# Altium schematic sheet MCU.SchDoc
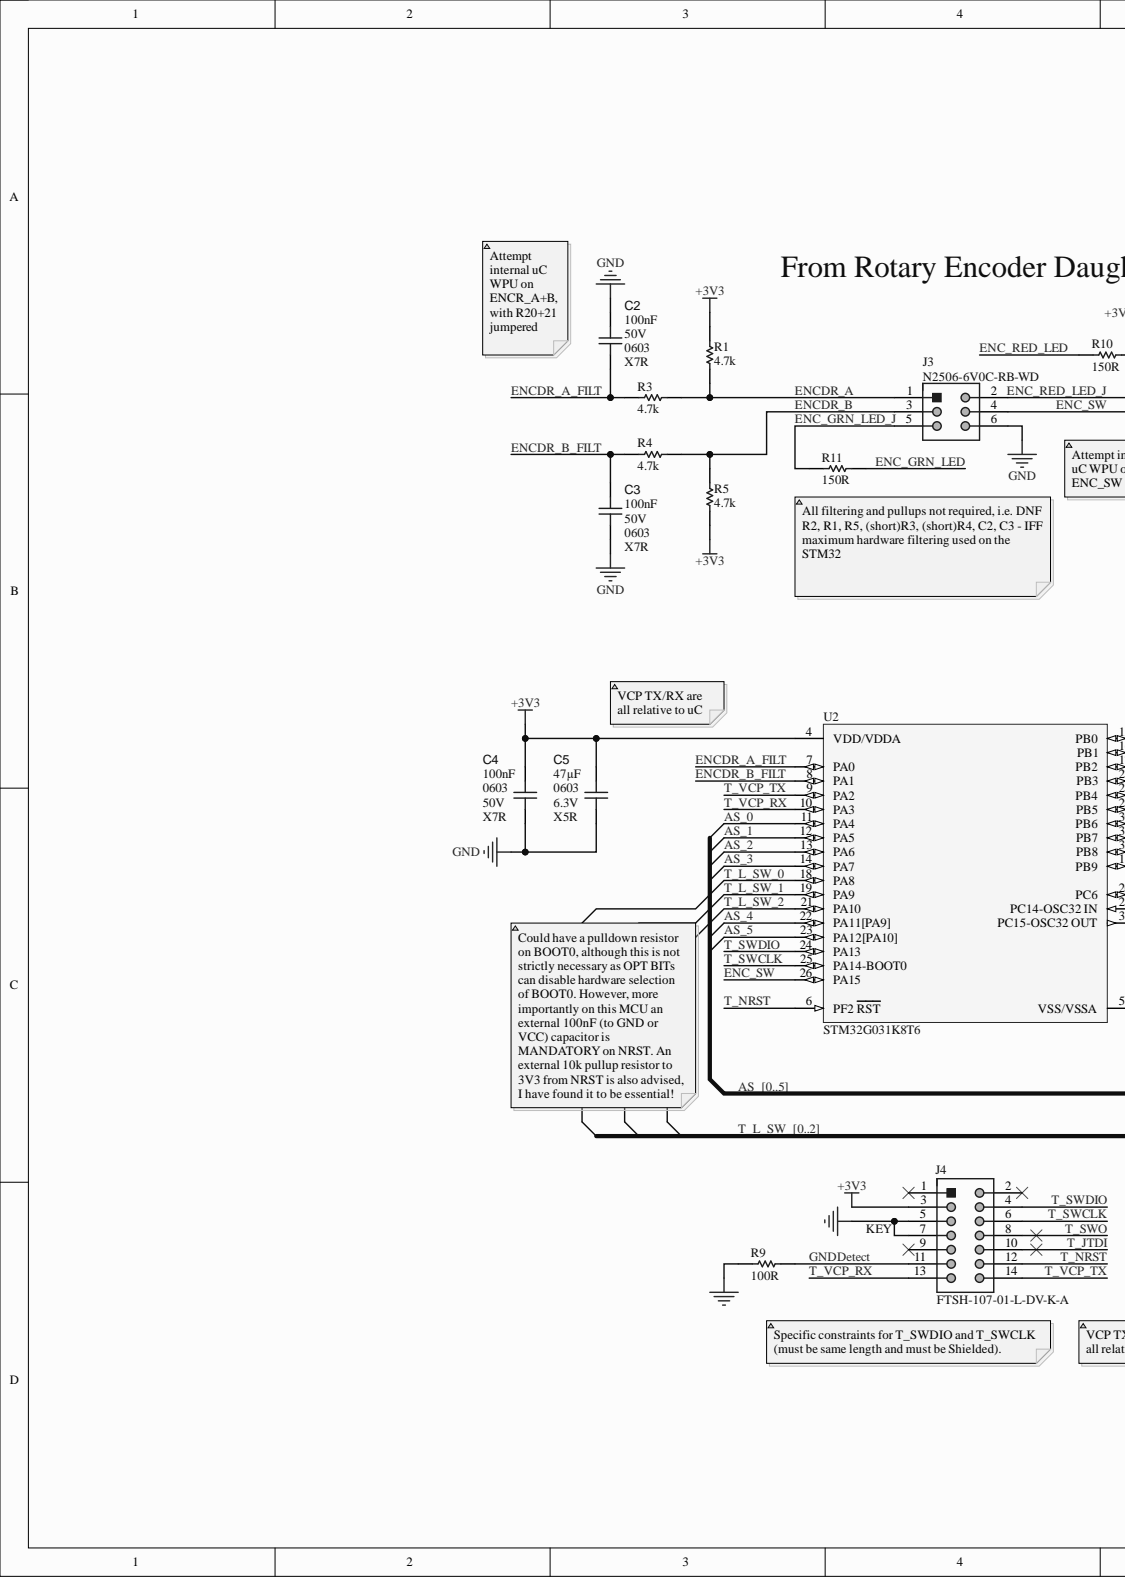
[diagram p0: MCU.SchDoc, left]
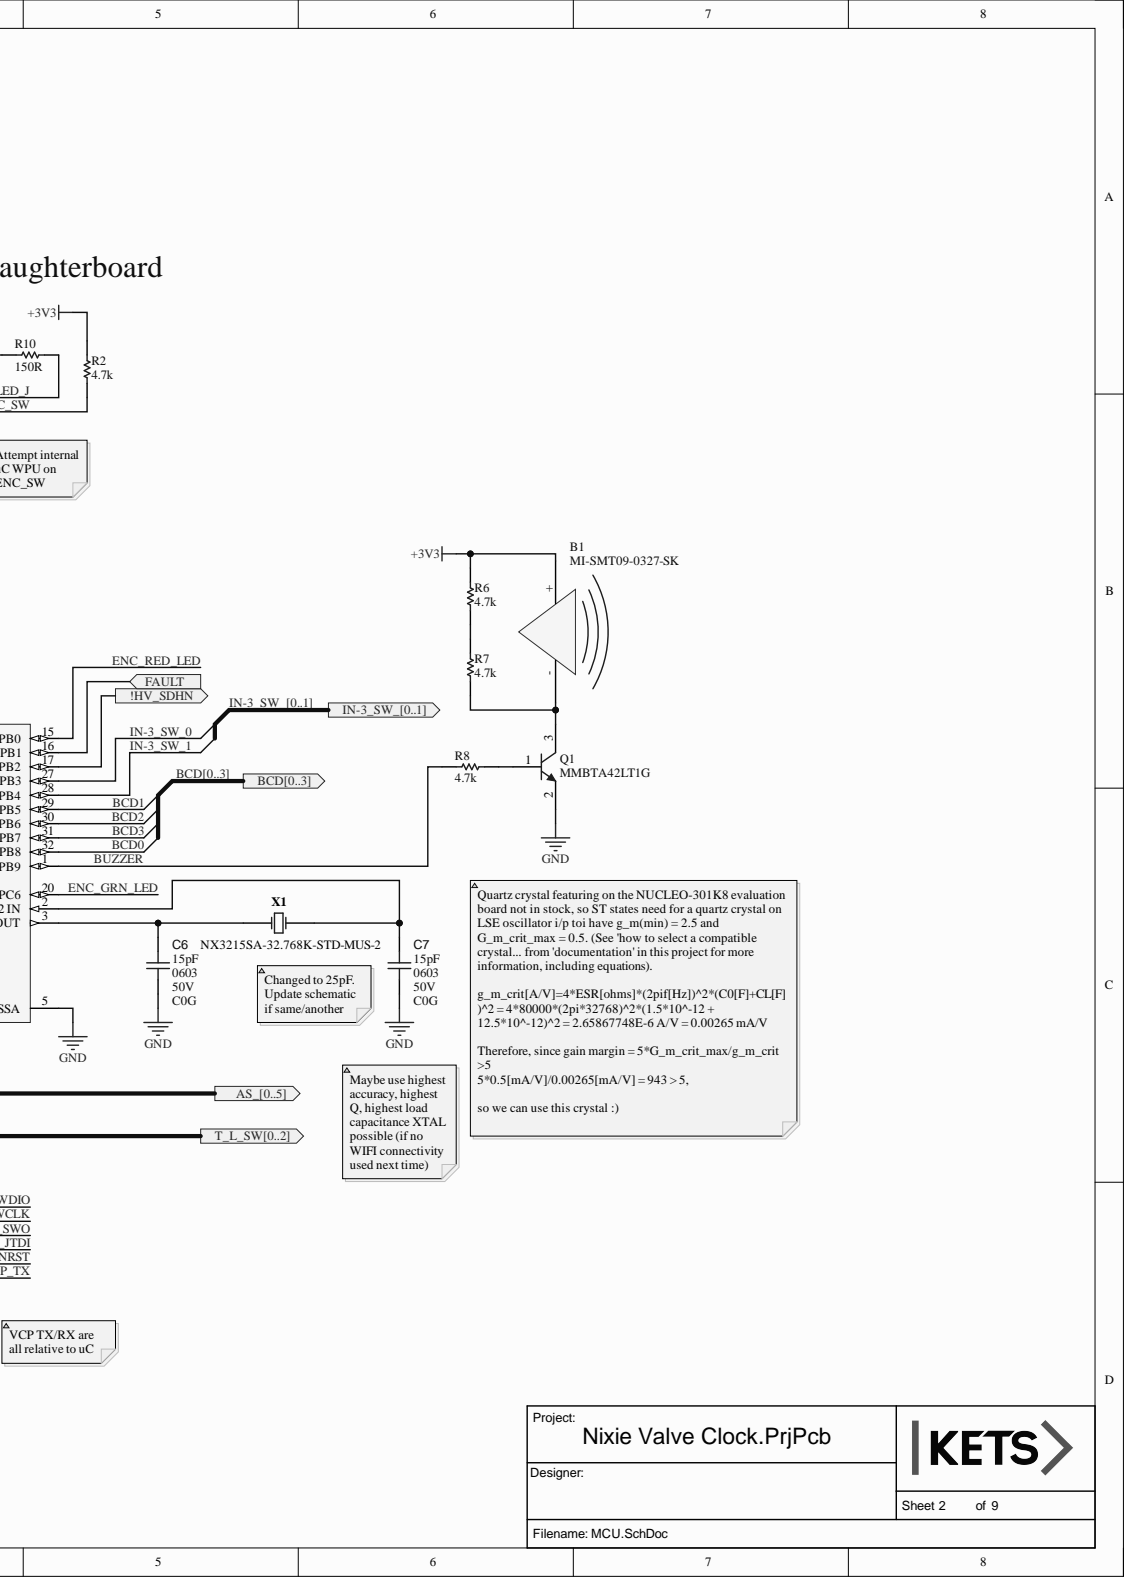
[diagram p1: MCU.SchDoc, right]

## Parts
B1  MI-SMT09-0327-SK  [INDP9696X53N]
C2  value 100nF  Case/Package: 0603  Dielectric: X7R  Rated DC Voltage (URdc): 50V  [CAPC1608X09N]  (hidden comment: CGA3E2X7R1H104K080AA)
C3  value 100nF  Case/Package: 0603  Dielectric: X7R  Rated DC Voltage (URdc): 50V  [CAPC1608X09N]  (hidden comment: CGA3E2X7R1H104K080AA)
C4  value 100nF  Case/Package: 0603  Dielectric: X7R  Rated DC Voltage (URdc): 50V  [CAPC1608X09N]  (hidden comment: CGA3E2X7R1H104K080AA)
C5  value 47µF  Case/Package: 0603  Dielectric: X5R  Voltage Rating: 6.3V  [CAPC1608X87X35NL15T15]  (hidden comment: 06036D476MAT2A)
C6  value 15pF  Case Code (Imperial): 0603  Dielectric: C0G  Voltage Rating: 50V  [CAPC1608X87X35NL15T15]  (hidden comment: C0603X150J5GACTU)
C7  value 15pF  Case Code (Imperial): 0603  Dielectric: C0G  Voltage Rating: 50V  [CAPC1608X87X35NL15T15]  (hidden comment: C0603X150J5GACTU)
J3  N2506-6V0C-RB-WD  [N2506-6V0C-RB-WD-Footprint-1]
J4  FTSH-107-01-L-DV-K-A  [FP-FTSH-107-01-L-DV-K-A-MFG]
Q1  MMBTA42LT1G  [ONSC-SOT-23-3-318-08_V]
R1  value 4.7k  [RESC1608X55X25NL10T15]  (hidden comment: Resistor 4k7 +/-1% 0603 100 mW)
R2  value 4.7k  [RESC1608X55X25NL10T15]  (hidden comment: Resistor 4k7 +/-1% 0603 100 mW)
R3  value 4.7k  [RESC1608X55X25NL10T15]  (hidden comment: Resistor 4k7 +/-1% 0603 100 mW)
R4  value 4.7k  [RESC1608X55X25NL10T15]  (hidden comment: Resistor 4k7 +/-1% 0603 100 mW)
R5  value 4.7k  [RESC1608X55X25NL10T15]  (hidden comment: Resistor 4k7 +/-1% 0603 100 mW)
R6  value 4.7k  [RESC1608X55X25NL10T15]  (hidden comment: Resistor 4k7 +/-1% 0603 100 mW)
R7  value 4.7k  [RESC1608X55X25NL10T15]  (hidden comment: Resistor 4k7 +/-1% 0603 100 mW)
R8  value 4.7k  [RESC1608X55X25NL10T15]  (hidden comment: Resistor 4k7 +/-1% 0603 100 mW)
R9  value 100R  [RESC1608X55X25NL10T15]  (hidden comment: ERJ-3EKF1000V)
R10  value 150R  [RESC1608X55X25NL10T15]  (hidden comment: CRCW0603150RFKEAHP)
R11  value 150R  [RESC1608X55X25NL10T15]  (hidden comment: CRCW0603150RFKEAHP)
U2  STM32G031K8T6  [TQFP80P900X900X160-32N]
X1  NX3215SA-32.768K-STD-MUS-2  [NX3215SA-32.768K-STD-MUS-2-Footprint-1]

## Ports
!HV_SDHN, AS_0, AS_1, AS_2, AS_3, AS_4, AS_5, AS_[0..5], BCD0, BCD1, BCD2, BCD3, BCD[0..3], FAULT, IN-3_SW_0, IN-3_SW_1, IN-3_SW_[0..1], T_L_SW0, T_L_SW1, T_L_SW2, T_L_SW[0..2]

## Nets
+3V3: B1.+ C4.1 C5.1 J4.3 R1.2 R2.2 R5.1 R6.2 U2.4(VDD/VDDA)
GND: C2.2 C3.1 C4.2 C5.2 C6.2 C7.2 J3.6 J4.5 J4.7 Q1.2 R9.1 U2.5(VSS/VSSA)
!HV_SDHN: U2.17(PB2) ; port !HV_SDHN
AS_0: U2.11(PA4) ; port AS_[0..5]  [also labelled AS_]
AS_1: U2.12(PA5) ; port AS_[0..5]  [also labelled AS_]
AS_2: U2.13(PA6) ; port AS_[0..5]  [also labelled AS_]
AS_3: U2.14(PA7) ; port AS_[0..5]  [also labelled AS_]
AS_4: U2.22(PA11[PA9]) ; port AS_[0..5]  [also labelled AS_]
AS_5: U2.23(PA12[PA10]) ; port AS_[0..5]  [also labelled AS_]
BCD0: U2.32(PB8) ; port BCD[0..3]  [also labelled BCD]
BCD1: U2.29(PB5) ; port BCD[0..3]  [also labelled BCD]
BCD2: U2.30(PB6) ; port BCD[0..3]  [also labelled BCD]
BCD3: U2.31(PB7) ; port BCD[0..3]  [also labelled BCD]
BUZZER: R8.1 U2.1(PB9)
ENC_GRN_LED: R11.2 U2.20(PC6)
ENC_GRN_LED_J: J3.5 R11.1
ENC_RED_LED: R10.2 U2.15(PB0)
ENC_RED_LED_J: J3.2 R10.1
ENC_SW: J3.4 R2.1 U2.26(PA15)
ENCDR_A: J3.1 R1.1 R3.2
ENCDR_A_FILT: C2.1 R3.1 U2.7(PA0)
ENCDR_B: J3.3 R4.2 R5.2
ENCDR_B_FILT: C3.2 R4.1 U2.8(PA1)
FAULT: U2.16(PB1) ; port FAULT
GNDDetect: J4.11 R9.2
IN-3_SW_0: U2.27(PB3) ; port IN-3_SW_[0..1]  [also labelled IN-3_SW_]
IN-3_SW_1: U2.28(PB4) ; port IN-3_SW_[0..1]  [also labelled IN-3_SW_]
T_JTDI: J4.10
T_L_SW_0: U2.18(PA8) ; port T_L_SW[0..2]  [also labelled T_L_SW_]
T_L_SW_1: U2.19(PA9) ; port T_L_SW[0..2]  [also labelled T_L_SW_]
T_L_SW_2: U2.21(PA10) ; port T_L_SW[0..2]  [also labelled T_L_SW_]
T_NRST: J4.12 U2.6(PF2_RST)
T_SWCLK: J4.6 U2.25(PA14-BOOT0)
T_SWDIO: J4.4 U2.24(PA13)
T_SWO: J4.8
T_VCP_RX: J4.13 U2.10(PA3)
T_VCP_TX: J4.14 U2.9(PA2)
NetB1_-: B1.- Q1.3 R7.1
NetC6_1: C6.1 U2.3(PC15-OSC32_OUT) X1.1
NetC7_1: C7.1 U2.2(PC14-OSC32_IN) X1.2
NetJ4_1: J4.1
NetJ4_2: J4.2
NetJ4_9: J4.9
NetQ1_1: Q1.1 R8.2
NetR6_1: R6.1 R7.2

## Library data of the parts
B1 = CMP-00030-00004-2: Magnetic Indicator SMT 9.6MM 3V; Component Kind: Standard; Mounting Technology: SMT; Pins: 2; RoHS Compliant: Yes; Library Name: KETS Quantum Security
C2-C4 = CMP-001-00101-1: Ceramic Capacitor, Multilayer, Ceramic, 50V, 10% +Tol, 10% -Tol, X7R, 15% TC, 0.1uF, Surface Mount, 0603; Multilayer: Yes; Reference Standard: AEC-Q200; Capacitor Type: Ceramic; Component Kind: Standard; Dielectric Material: Ceramic; Height: 0.8mm; JESD-609 Code: e3; Length: 1.6mm; Max Operating Temperature: 125°C; Min Operating Temperature: -55°C; Mounting Technology: Surface Mount; Package Shape: Rectangular; Package Style: SMTMeter; Packaging: Tape and Reel; Pins: 2; Reach SVHC Compliant: Yes; RoHS Compliant: Yes; Temperature Characteristics Code: X7R; Terminal Finish: Nickel; Termination: Wraparound; Tolerance: 10%; Tolerance  (fill in as +-): 10%; Tolerance (fill in as +-): 10%; Voltage Rating: 50V; Width: 0.8mm; Library Name: KETS Quantum Security
C5 = CMP-00388-1: 0603 (1608Metric) CERAMIC CAPACITOR ceramic capacitor 6.3V 47uF ±20% X5R; Capacitor Type: MLCC; Component Kind: Standard; Dielectric Material: Ceramic; Height: 1.02mm; Length: 1.6mm; Lifecycle Status: Production; Material: Ceramic; Max Operating Temperature: 85°C; Min Operating Temperature: -55°C; Mounting Technology: Surface Mount; Number of Terminals: 2; Pins: 2; REACH SVHC: Yes; RoHS: Compliant; RoHS Compliant: Yes; Schedule B: 8532240020; Tolerance: 20%; Voltage Rating (DC): 6.3V; Width: 800µm; Library Name: KETS Quantum Security
C6 C7 = CMP-00385-1: Cap Ceramic 15pF 50V C0G 5% Pad SMD 0603 Flexible Termination 125C Extreme Low ESR T/R; Case Code (Metric): 1608; Case/Package: 0603; China RoHS: Compliant; Component Kind: Standard; Depth: 800µm; Dielectric Material: Ceramic; Features: Soft Termination; Height: 800µm; Lead Free: Lead Free; Length: 1.6mm; Lifecycle Status: Production; Max Operating Temperature: 125°C; Min Operating Temperature: -55°C; Mounting Technology: Surface Mount; Number of Terminals: 2; Packaging: Tape & Reel; Pins: 2; Radiation Hardening: No; REACH SVHC: Yes; RoHS: Compliant; RoHS Compliant: Yes; Schedule B: 8532240020, 8532240020; Termination: SMD/SMT; Thickness: 863.6µm; Tolerance: 5%; Voltage Rating (DC): 50V; Width: 800µm; Library Name: KETS Quantum Security
J3 = CMP-002-00208-1: 80001386988 N2506-6V0C-Rb-Wd=06//Ht/Vsmt/30U/Rohs/Cap/T&r; Connector Type: Male Pin; Current Rating: 5A; Insulation Resistance: 1GΩ; Number of Contacts: 6; Pitch: 2.54mm; Plating Thickness: 762nm; Row Spacing: 6.86mm; Color: Black; Component Kind: Standard; Contact Gender: Male; Contact Material: Gold, Tin; Contact Mating Length: 6.1722mm; Contact Plating: Gold; Height: 11.06mm; Lifecycle Status: Production; Max Operating Temperature: 105°C; Min Operating Temperature: -55°C; Mounting Technology: Surface Mount; Number of Positions: 6; Number of Rows: 2; Pins: 6; REACH SVHC: Yes; RoHS: Compliant; Terminal Pitch: 2.54mm; Termination: Solder; Library Name: KETS Quantum Security
J4 = CMP-002-00203-1: Conn Unshrouded Header HDR 14 POS 1.27mm Solder ST Top Entry SMD TIGER CLAW™ Tube; Manufacturer Lifecycle Status: PRODUCTION; Current Rating: 3.4A; Gender: Male; Number of Contacts: 14; Component Kind: Standard; Contact Material: Gold, Nickel; Contact Plating: Gold; Height: 6.38mm; Lead Free: Lead Free; Lifecycle Status: Production; Max Operating Temperature: 125°C; Min Operating Temperature: -55°C; Number of Rows: 2; Orientation: Vertical; Pins: 14; Pitch: 1.27mm; RoHS: Compliant; Schedule B: 8536694040; Termination: Solder; Library Name: KETS Quantum Security
Q1 = CMP-013-00062-1: Bipolar (BJT) Single Transistor, General Purpose, NPN, 300 V, 500 mA, 225 mW, SOT-23, Surface Mount; Manufacturer Lifecycle Status: ACTIVE; Clock Frequency: 50MHz; Collector Base Voltage (VCBO): 300V; Collector Emitter Saturation Voltage: 500mV; Current Rating: 500mA; Emitter Base Voltage (VEBO): 6V; hFE Min: 40; Max Power Dissipation: 300mW; Number of Elements: 1; Case/Package: SOT-23-3; China RoHS: Compliant; Collector Emitter Breakdown Voltage: 300V; Collector Emitter Voltage (VCEO): 300V; Component Kind: Standard; Contact Plating: Tin; Element Configuration: Single; Frequency: 50MHz; Gain Bandwidth Product: 50MHz; Height: 1.016mm; Lead Free: Lead Free; Length: 3.0226mm; Lifecycle Status: Production; Max Breakdown Voltage: 300V; Max Collector Current: 500mA; Max Operating Temperature: 150°C; Min Operating Temperature: -55°C; Number of Terminals: 3; Packaging: Tape and Reel; Pins: 3; Polarity: NPN; Radiation Hardening: No; REACH SVHC: Yes; RoHS: Compliant; Schedule B: 8541210080, 8541210080; Transition Frequency: 50MHz; Voltage Rating (DC): 300V; Weight: 4.535924g; Width: 1.397mm; Library Name: KETS Quantum Security
R1-R8 = CMP-009-00031-13: Chip Resistor, 4.7 KOhm, +/- 1%, 0.1 W, -55 to 155 degC, 0603 (1608 Metric); Power: 100mW; Case/Package: 0603; Component Kind: Standard; Max Operating Temperature: 155°C; Min Operating Temperature: -55°C; Mounting Technology: SM; Pins: 2; RoHS Compliant: True; Tolerance: 1%; Library Name: KETS Quantum Security
R9 = CMP-00265-1: Fixed Resistor, Metal Glaze/thick Film, 0.1W, 100ohm, 75V, 1% +/-Tol, 100ppm/Cel, Surface Mount, 0603; Package Height: 0.45mm; Package Length: 1.6mm; Package Width: 0.8mm; Power: 100mW; Rated Temperature: 70°C; Reference Standard: AEC-Q200; Temperature Coefficient (ppm/Cel): 100; Case/Package: 0603; Component Kind: Standard; Construction: Rectangular; JESD-609 Code: e3; Max Operating Temperature: 155°C; Min Operating Temperature: -55°C; Mounting Technology: Surface Mount; Package Shape: Rectangular; Packaging: Tape and Reel; Pins: 2; Reach SVHC Compliant: Yes; Resistor Type: FIXED RESISTOR; RoHS Compliant: Yes; Technology: METAL GLAZE/THICK FILM; Terminal Finish: Nickel; Termination: Wraparound; Tolerance: 1%; Voltage Rating: 75V; Working Voltage: 75V; Library Name: KETS Quantum Security
R10 R11 = CMP-00382-2: Res Thick Film 0603 150 Ohm 1% 1/3W ±100ppm/°C Molded SMD SMD Paper T/R; Max Power Dissipation: 250mW; Power: 100mW; Power Dissipation: 330mW; Voltage Rating: 75V; Case Code (Imperial): 0603; Case Code (Metric): 1608; Case/Package: 0603; China RoHS: Non-Compliant; Component Kind: Standard; Composition: Thick Film; Contact Plating: Tin; Depth: 850µm; Features: Automotive AEC-Q200; Height: 450µm; Lead Free: Lead Free; Length: 1.55mm; Lifecycle Status: Production; Max Operating Temperature: 155°C; Military Standard: Not; Min Operating Temperature: -55°C; Mounting Technology: Surface Mount; Number of Terminals: 2; Number of Terminations: 2; Packaging: Cut Tape; Pins: 2; Power Rating: 330mW; Radiation Hardening: No; REACH SVHC: Yes; RoHS: Compliant; RoHS Compliant: Yes; Schedule B: 8533210045, 8533210045; Temperature Coefficient: 100ppm/°C; Termination: SMD/SMT; Tolerance: 1%; Voltage Rating (DC): 75V; Weight: 2.012816mg; Width: 850µm; Working Voltage: 75V; Library Name: KETS Quantum Security
U2 = CMP-00383-2: RISC Microcontroller, 32-Bit, FLASH, CORTEX-M0 CPU, 64MHz, CMOS, PQFP32; ADC Channels (Resolution): 16-Ch 12-Bit; Clock Frequency: 48MHz; Core Architecture: ARM Cortex-M0+; Data Bus Width: 32b; Data Memory: 8K; EEPROM Memory Size: 0B; Flash Memory Size: 64kB; Interface: I2C, I2S, IrDA, LIN, SMBus, SPI, UART, USART; Max Junction Temperature (Tj): 105°C; Max Supply Current: 6.9mA; Max Supply Voltage: 3.6V; Min Supply Voltage: 1.7V; Number of A/D Converters: 1; Number of ADC Channels: 16; Number of I2C Channels: 2; Number of I/Os: 3; Number of PWM Channels: 17; Number of Timers/Counters: 9; Operating Supply Voltage: 1.8V; Peripherals: POR; Program Memory: 64K; RAM Size: 8kB; Register Bit Depth: 32; Ambient Temperature Range High: 85°C; China RoHS: Compliant; Component Kind: Standard; Frequency: 64MHz; Height: 1.6mm; Height - Seated (Max): 1.6mm; Length: 7mm; Lifecycle Status: Production; Max Operating Temperature: 85°C; Maximum Clock Frequency: 64MHz; Memory Format: FLASH; Memory Size: 64kB; Min Operating Temperature: -40°C; Number of SPI Channels: 2; Number of Terminals: 32; Number of UART Channels: 3; Number of USART Channels: 2; Pins: 32; REACH SVHC: Yes; RoHS: Compliant; Schedule B: 8542310000; Supply Voltage Max: 3.6V; Supply Voltage Min: 1.7V; Terminal Pitch: 800µm; Width: 7mm; Library Name: KETS Quantum Security
X1 = CMP-003-00032-2: Crystal 0.032768MHz ±20ppm (Tol) 12.5pF FUND 80000Ohm Automotive AEC-Q200 2-Pin SMD; ESR: 80k; ESR (Equivalent Series Resistance): 80kΩ; Frequency: 32.768kHz; Frequency Stability: 400ppm; Frequency Tolerance: 0.002%; Case/Package: SMD/SMT; Component Kind: Standard; Height: 900µm; Length: 3.2004mm; Lifecycle Status: Production; Load Capacitance: 12.5pF; Max Operating Temperature: 125°C; Min Operating Temperature: -40°C; Mounting Technology: Surface Mount; Packaging: Tape & Reel (TR); Pins: 2; REACH SVHC: Yes; RoHS: Compliant; RoHS Compliant: Yes; Schedule B: 8541600080; Tolerance: 0.002%; Width: 1.4986mm; Library Name: KETS Quantum Security
